# Altium schematic sheet Power.SchDoc(Power) (continued)
## Library data of the parts (continued)
R4 = RC0805FR-071K5L: Resistor, thick film, SMD 0805 (2012), 1.5 kΩ, 0.125 W, ±1 %, RC0805FR-071K5L; Manufacturer: Yageo Group; Manufacturer Package Identifier: CRCW0805-e3; Max Power Dissipation: 125mW; Temperature Coefficient: 100ppm/°C; Tolerance: 1%; Value: 1.5kΩ; Voltage Rating: 150V; Case Code (Imperial): 0805; Case/Package: 0805; Category: Chip SMD Resistors; China RoHS: Non-Compliant; Component Kind: Standard; ComponentLink1Description: https://datasheet.octopart.com:443/RC0805FR-071K5L-Yageo-datasheet-175887523.pdf?src-supplier=Newark; ComponentLink1URL: https://datasheet.octopart.com:443/RC0805FR-071K5L-Yageo-datasheet-175887523.pdf?src-supplier=Newark; ComponentLink2Description: https://datasheet.octopart.com:443/RC0805FR-071K5L-Yageo-datasheet-8330313.pdf?src-supplier=Newark; ComponentLink2URL: https://datasheet.octopart.com:443/RC0805FR-071K5L-Yageo-datasheet-8330313.pdf?src-supplier=Newark; ComponentLink3Description: https://datasheet.octopart.com:443/RC0805FR-071K5L-Yageo-datasheet-62067350.pdf?src-supplier=element14+APAC; ComponentLink3URL: https://datasheet.octopart.com:443/RC0805FR-071K5L-Yageo-datasheet-62067350.pdf?src-supplier=element14+APAC; ComponentLink4Description: https://datasheet.octopart.com:443/RC0805FR-071K5L-Yageo-datasheet-103092701.pdf?src-supplier=Upverter; ComponentLink4URL: https://datasheet.octopart.com:443/RC0805FR-071K5L-Yageo-datasheet-103092701.pdf?src-supplier=Upverter; ComponentLink5Description: https://datasheet.octopart.com:443/RC0805FR-071K5L-Yageo-Group-datasheet-190305687.pdf?src-supplier=Future+Electronics; ComponentLink5URL: https://datasheet.octopart.com:443/RC0805FR-071K5L-Yageo-Group-datasheet-190305687.pdf?src-supplier=Future+Electronics; ComponentLink6Description: https://datasheet.octopart.com:443/RC0805FR-071K5L-Yageo-datasheet-164759695.pdf?src-supplier=Future+Electronics; ComponentLink6URL: https://datasheet.octopart.com:443/RC0805FR-071K5L-Yageo-datasheet-164759695.pdf?src-supplier=Future+Electronics; ComponentLink7Description: https://datasheet.octopart.com:443/RC0805FR-071K5L-Yageo-datasheet-12592811.pdf?src-supplier=Future+Electronics; ComponentLink7URL: https://datasheet.octopart.com:443/RC0805FR-071K5L-Yageo-datasheet-12592811.pdf?src-supplier=Future+Electronics; ComponentLink8Description: https://datasheet.octopart.com:443/RC0805FR-071K5L-Yageo-datasheet-8324252.pdf?src-supplier=Future+Electronics; ComponentLink8URL: https://datasheet.octopart.com:443/RC0805FR-071K5L-Yageo-datasheet-8324252.pdf?src-supplier=Future+Electronics; ComponentLink9Description: https://datasheet.octopart.com:443/RC0805FR-071K5L-Yageo-Group-datasheet-189192902.pdf?src-supplier=LCSC; ComponentLink9URL: https://datasheet.octopart.com:443/RC0805FR-071K5L-Yageo-Group-datasheet-189192902.pdf?src-supplier=LCSC; ComponentLink10Description: https://datasheet.octopart.com:443/RC0805FR-071K5L-Yageo-datasheet-79472498.pdf?src-supplier=Megastar; ComponentLink10URL: https://datasheet.octopart.com:443/RC0805FR-071K5L-Yageo-datasheet-79472498.pdf?src-supplier=Megastar; ComponentLink11Description: https://datasheet.octopart.com:443/RC0805FR-071K5L-Yageo-datasheet-27714392.pdf?src-supplier=Seeed+Studio; ComponentLink11URL: https://datasheet.octopart.com:443/RC0805FR-071K5L-Yageo-datasheet-27714392.pdf?src-supplier=Seeed+Studio; ComponentLink12Description: https://datasheet.octopart.com/RC0805FR-071K5L-Yageo-datasheet-73766026.pdf; ComponentLink12URL: https://datasheet.octopart.com/RC0805FR-071K5L-Yageo-datasheet-73766026.pdf; ComponentLink13Description: https://datasheet.octopart.com:443/RC0805FR-071K5L-Yageo-datasheet-13691069.pdf?src-supplier=Farnell; ComponentLink13URL: https://datasheet.octopart.com:443/RC0805FR-071K5L-Yageo-datasheet-13691069.pdf?src-supplier=Farnell; ComponentLink14Description: https://datasheet.octopart.com:443/RC0805FR-071K5L-Yageo-datasheet-118657.pdf?src-supplier=DigiKey; ComponentLink14URL: https://datasheet.octopart.com:443/RC0805FR-071K5L-Yageo-datasheet-118657.pdf?src-supplier=DigiKey; ComponentLink15Description: https://datasheet.octopart.com:443/RC0805FR-071K5L-Yageo-datasheet-502840.pdf?src-supplier=element14; ComponentLink15URL: https://datasheet.octopart.com:443/RC0805FR-071K5L-Yageo-datasheet-502840.pdf?src-supplier=element14; ComponentLink16Description: http://sigma.octopart.com:80/conflict_mineral_statement/Yageo-company-3.pdf?src-supplier=Compliance+Statements; ComponentLink16URL: http://sigma.octopart.com:80/conflict_mineral_statement/Yageo-company-3.pdf?src-supplier=Compliance+Statements; ComponentLink17Description: http://sigma.octopart.com:80/rohs_statement/Yageo-company-2.pdf?src-supplier=Compliance+Statements; ComponentLink17URL: http://sigma.octopart.com:80/rohs_statement/Yageo-company-2.pdf?src-supplier=Compliance+Statements; ComponentLink18Description: https://datasheet.octopart.com:443/CRCW08057K32FKEA-Vishay-datasheet-175423584.pdf?src-supplier=IHS; ComponentLink18URL: https://datasheet.octopart.com:443/CRCW08057K32FKEA-Vishay-datasheet-175423584.pdf?src-supplier=IHS; ComponentLink19Description: https://datasheet.octopart.com:443/CRCW08057K32FKEA.-Vishay-datasheet-83961561.pdf?src-supplier=element14+APAC; ComponentLink19URL: https://datasheet.octopart.com:443/CRCW08057K32FKEA.-Vishay-datasheet-83961561.pdf?src-supplier=element14+APAC; ComponentLink20Description: https://datasheet.octopart.com:443/CRCW08057K32FKEA-Vishay-datasheet-62317937.pdf?src-supplier=element14+APAC; ComponentLink20URL: https://datasheet.octopart.com:443/CRCW08057K32FKEA-Vishay-datasheet-62317937.pdf?src-supplier=element14+APAC; ComponentLink21Description: https://datasheet.octopart.com:443/CRCW08057K32FKEA-Vishay-datasheet-14722531.pdf?src-supplier=element14+APAC; ComponentLink21URL: https://datasheet.octopart.com:443/CRCW08057K32FKEA-Vishay-datasheet-14722531.pdf?src-supplier=element14+APAC; ComponentLink22Description: https://datasheet.octopart.com:443/CRCW08057K32FKEA.-Vishay-datasheet-78776209.pdf?src-supplier=Newark; ComponentLink22URL: https://datasheet.octopart.com:443/CRCW08057K32FKEA.-Vishay-datasheet-78776209.pdf?src-supplier=Newark; ComponentLink23Description: https://datasheet.octopart.com:443/CRCW08057K32FKEA-Vishay-datasheet-66077789.pdf?src-supplier=Newark; ComponentLink23URL: https://datasheet.octopart.com:443/CRCW08057K32FKEA-Vishay-datasheet-66077789.pdf?src-supplier=Newark; ComponentLink24Description: https://datasheet.octopart.com:443/CRCW08057K32FKEA-Vishay-datasheet-11761042.pdf?src-supplier=Newark; ComponentLink24URL: https://datasheet.octopart.com:443/CRCW08057K32FKEA-Vishay-datasheet-11761042.pdf?src-supplier=Newark; ComponentLink25Description: https://datasheet.octopart.com:443/CRCW08057K32FKEA-Vishay-datasheet-11516975.pdf?src-supplier=Newark; ComponentLink25URL: https://datasheet.octopart.com:443/CRCW08057K32FKEA-Vishay-datasheet-11516975.pdf?src-supplier=Newark; ComponentLink26Description: https://datasheet.octopart.com:443/CRCW08057K32FKEA-Vishay-datasheet-41302739.pdf?src-supplier=Newark; ComponentLink26URL: https://datasheet.octopart.com:443/CRCW08057K32FKEA-Vishay-datasheet-41302739.pdf?src-supplier=Newark; ComponentLink27Description: https://datasheet.octopart.com:443/CRCW08057K32FKEA-Vishay-datasheet-33013972.pdf?src-supplier=Newark; ComponentLink27URL: https://datasheet.octopart.com:443/CRCW08057K32FKEA-Vishay-datasheet-33013972.pdf?src-supplier=Newark; ComponentLink28Description: https://datasheet.octopart.com:443/CRCW08057K32FKEA-Vishay-datasheet-62316122.pdf?src-supplier=Future+Electronics; ComponentLink28URL: https://datasheet.octopart.com:443/CRCW08057K32FKEA-Vishay-datasheet-62316122.pdf?src-supplier=Future+Electronics; ComponentLink29Description: https://datasheet.octopart.com:443/CRCW08057K32FKEA-Vishay-datasheet-5300368.pdf?src-supplier=Future+Electronics; ComponentLink29URL: https://datasheet.octopart.com:443/CRCW08057K32FKEA-Vishay-datasheet-5300368.pdf?src-supplier=Future+Electronics; ComponentLink30Description: https://datasheet.octopart.com:443/CRCW08057K32FKEA-Vishay-Dale-datasheet-47688880.pdf?src-supplier=RS+(Formerly+Allied+Electronics); ComponentLink30URL: https://datasheet.octopart.com:443/CRCW08057K32FKEA-Vishay-Dale-datasheet-47688880.pdf?src-supplier=RS+(Formerly+Allied+Electronics); ComponentLink31Description: https://datasheet.octopart.com:443/CRCW08057K32FKEA-Vishay-datasheet-12001583.pdf?src-supplier=RS+(Formerly+Allied+Electronics); ComponentLink31URL: https://datasheet.octopart.com:443/CRCW08057K32FKEA-Vishay-datasheet-12001583.pdf?src-supplier=RS+(Formerly+Allied+Electronics); ComponentLink32Description: https://datasheet.octopart.com:443/CRCW08057K32FKEA-Vishay-datasheet-5306405.pdf?src-supplier=RS+(Formerly+Allied+Electronics); ComponentLink32URL: https://datasheet.octopart.com:443/CRCW08057K32FKEA-Vishay-datasheet-5306405.pdf?src-supplier=RS+(Formerly+Allied+Electronics); ComponentLink33Description: https://datasheet.octopart.com:443/CRCW08057K32FKEA-Vishay-datasheet-11540273.pdf?src-supplier=Arrow+Electronics; ComponentLink33URL: https://datasheet.octopart.com:443/CRCW08057K32FKEA-Vishay-datasheet-11540273.pdf?src-supplier=Arrow+Electronics; ComponentLink34Description: https://datasheet.octopart.com:443/CRCW08057K32FKEA-Vishay-datasheet-8445837.pdf?src-supplier=element14; ComponentLink34URL: https://datasheet.octopart.com:443/CRCW08057K32FKEA-Vishay-datasheet-8445837.pdf?src-supplier=element14; ComponentLink35Description: https://datasheet.octopart.com:443/CRCW08057K32FKEA-Vishay-datasheet-5377306.pdf?src-supplier=Garrett; ComponentLink35URL: https://datasheet.octopart.com:443/CRCW08057K32FKEA-Vishay-datasheet-5377306.pdf?src-supplier=Garrett; ComponentLink36Description: https://datasheet.octopart.com:443/CRCW08057K32FKEA-Vishay-datasheet-148188.pdf?src-supplier=Garrett; ComponentLink36URL: https://datasheet.octopart.com:443/CRCW08057K32FKEA-Vishay-datasheet-148188.pdf?src-supplier=Garrett; ComponentLink37Description: https://datasheet.octopart.com:443/CRCW08057K32FKEA-Vishay-datasheet-34203.pdf?src-supplier=Garrett; ComponentLink37URL: https://datasheet.octopart.com:443/CRCW08057K32FKEA-Vishay-datasheet-34203.pdf?src-supplier=Garrett; ComponentLink38Description: https://datasheet.octopart.com:443/CRCW08057K32FKEA-Vishay-datasheet-10380026.pdf?src-supplier=Farnell; ComponentLink38URL: https://datasheet.octopart.com:443/CRCW08057K32FKEA-Vishay-datasheet-10380026.pdf?src-supplier=Farnell; ComponentLink39Description: https://datasheet.octopart.com:443/CRCW08057K32FKEA-Vishay-datasheet-5299586.pdf?src-supplier=Farnell; ComponentLink39URL: https://datasheet.octopart.com:443/CRCW08057K32FKEA-Vishay-datasheet-5299586.pdf?src-supplier=Farnell; ComponentLink40Description: http://sigma.octopart.com:80/rohs_statement/Vishay-company-15.pdf?src-supplier=Compliance+Statements; ComponentLink40URL: http://sigma.octopart.com:80/rohs_statement/Vishay-company-15.pdf?src-supplier=Compliance+Statements; Composition: Thick Film; Contact Plating: Tin; Country of Origin: Israel; Depth: 1.25mm; Export Control Classification Number (ECCN) Code: EAR99; Features: Automotive AEC-Q200; Harmonized Tariff Schedule (HTS) Code: 8533.21.00.30; Height: 500µm; Introduction Date: 2001-04-25; Lead Free: Lead Free; Length: 2mm; Lifecycle Status: Production; Manufacturer Part Number: RC0805FR-071K5L; Max Operating Temperature: 155°C; Military Standard: Not; Min Operating Temperature: -55°C; Mounting Technology: Surface Mount; Number of Terminals: 2; Number of Terminations: 2; Packaging: Cut Tape; Pins: 2; Power Rating: 125mW; Pricing: 1=0.1, 10=0.034, 25=0.0256, 50=0.0208, 100=0.0171, 250=0.01344, 500=0.01136, 1000=0.00973 (USD); Radiation Hardening: No; Ratings: AEC-Q200; REACH SVHC: Unaffected; RoHS: Compliant; Schedule B: 8533210045, 8533210045|8533210045, 8533210045|8533210045|8533210045, 8533210045|8533210045|8533210045|8533210045; Stock: 497740; Supplier: DigiKey; Supplier Part Number: 311-1.50KCRDKR-ND; Termination: SMD/SMT; Voltage Rating (AC): 150V; Voltage Rating (DC): 150V; Weight: 5.499807mg; Width: 1.25mm; Working Voltage: 150V; Library Name: Fuzz_Pedal_Lib.SchLib
